# Altium schematic sheet transmitter.SchDoc(transmitter)
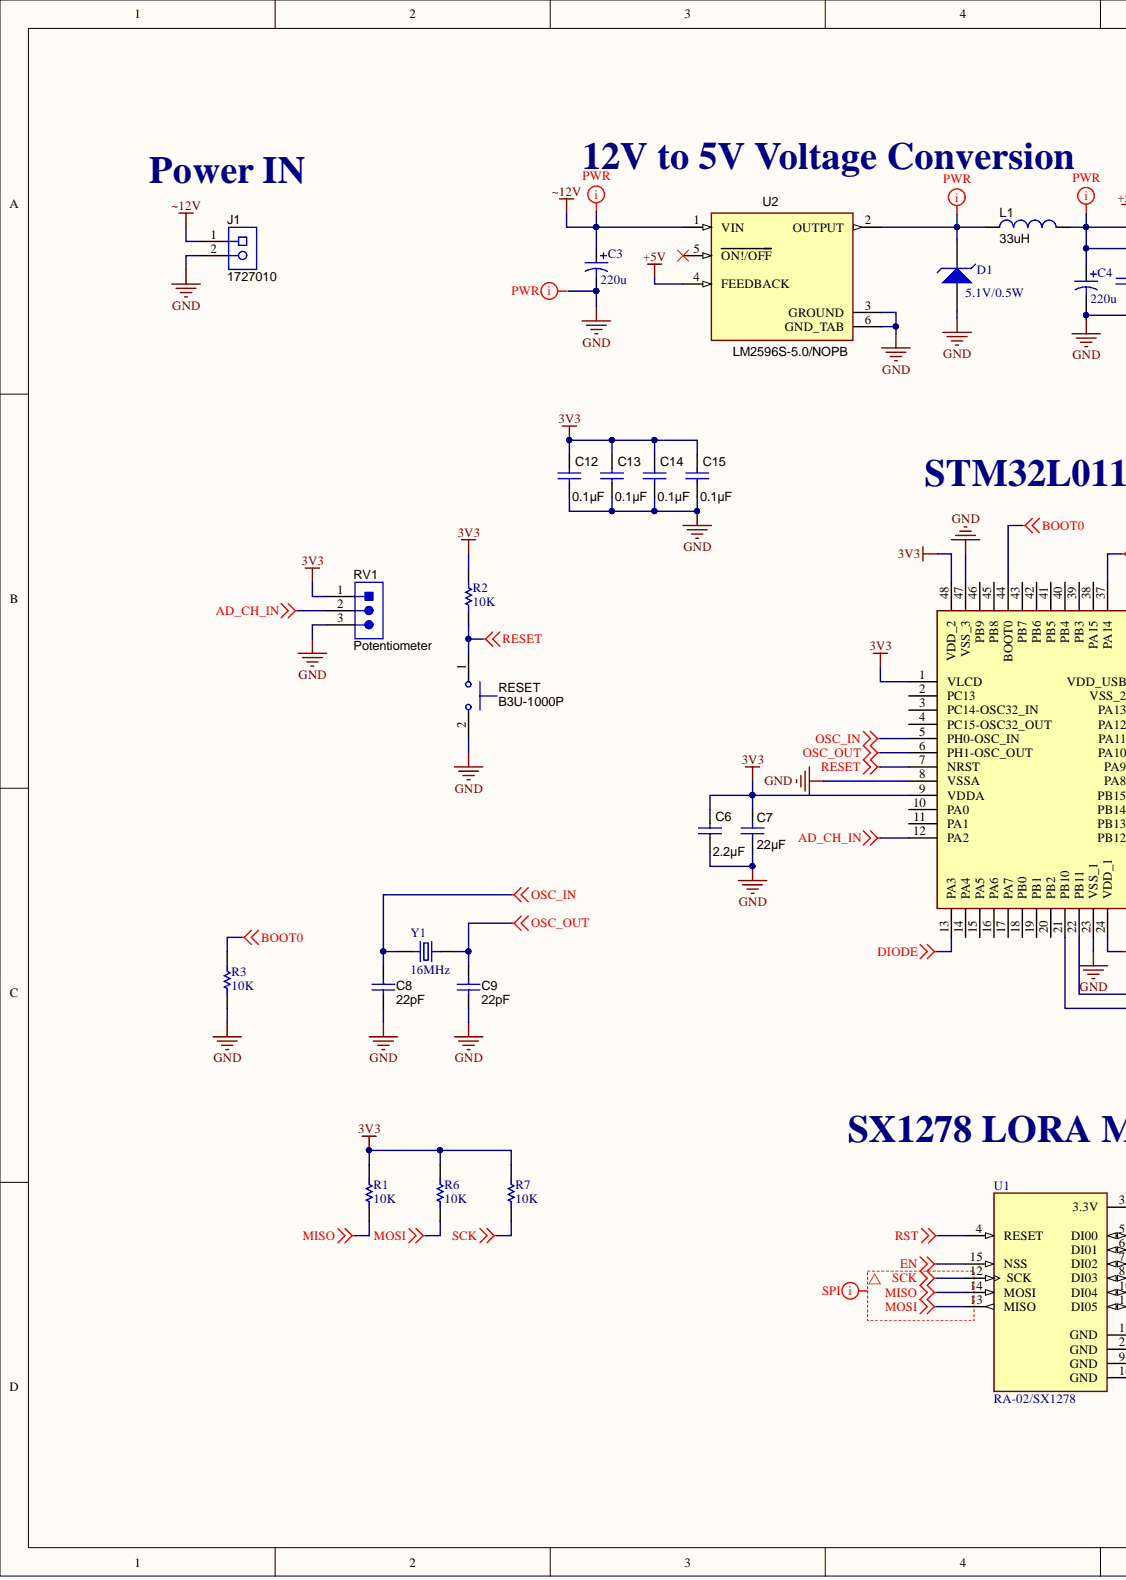
[diagram p0: transmitter.SchDoc, left]
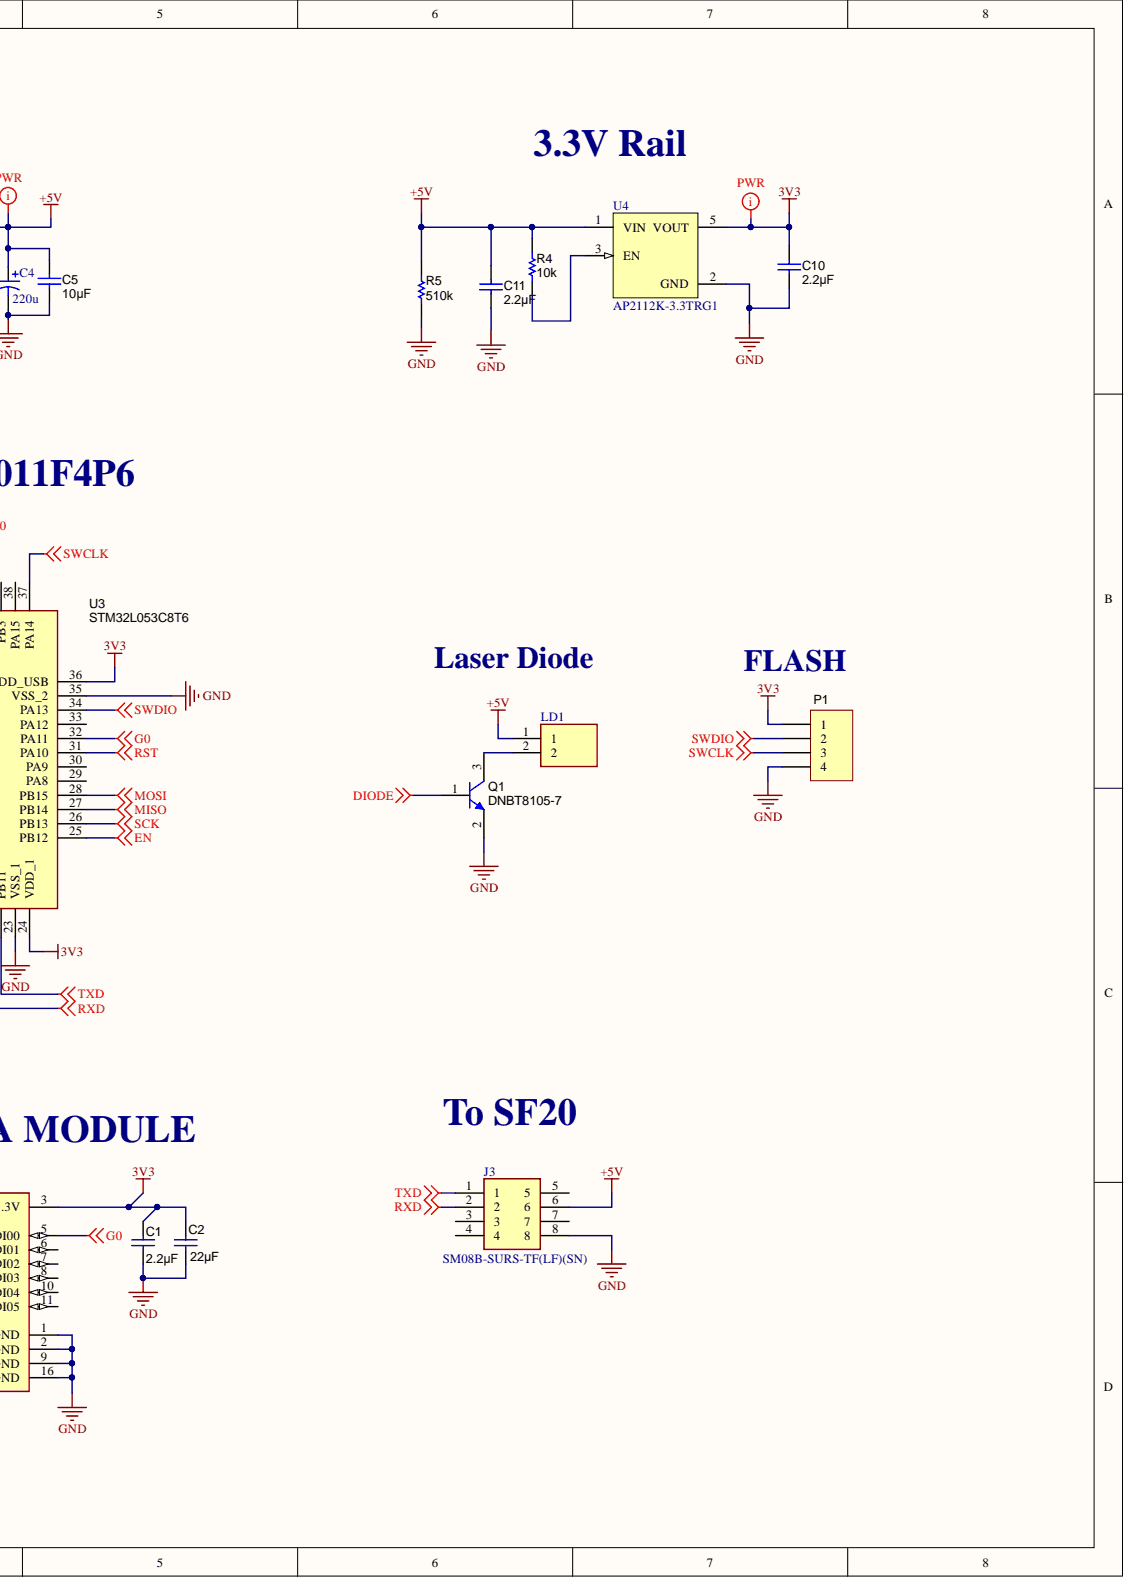
[diagram p1: transmitter.SchDoc, right]

## Parts
C1  ValueDisplayed: 2.2µF  [0603]  (hidden comment: C1608X6S1C225K080AC)
C2  ValueDisplayed: 22µF  [1206_180]  (hidden comment: C3216X5R1V226M160AC)
C3  220u  [CAPPRD250W50D630H1120B]
C4  220u  [CAPPRD250W50D630H1120B]
C5  ValueDisplayed: 10µF  [0805_HV]  (hidden comment: EMK212BB7106MG-T)
C6  ValueDisplayed: 2.2µF  [0603]  (hidden comment: C1608X6S1C225K080AC)
C7  ValueDisplayed: 22µF  [1206_180]  (hidden comment: C3216X5R1V226M160AC)
C8  value 22pF  ValueDisplayed: 22pF  [0805_HV]  (hidden comment: 0805YD225KAT2A)
C9  value 22pF  ValueDisplayed: 22pF  [0805_HV]  (hidden comment: 0805YD225KAT2A)
C10  ValueDisplayed: 2.2µF  [0805_HV]  (hidden comment: 0805YD225KAT2A)
C11  ValueDisplayed: 2.2µF  [0805_HV]  (hidden comment: 0805YD225KAT2A)
C12  ValueDisplayed: 0.1µF  [0603]  (hidden comment: C1608X6S1C225K080AC)
C13  ValueDisplayed: 0.1µF  [0603]  (hidden comment: C1608X6S1C225K080AC)
C14  ValueDisplayed: 0.1µF  [0603]  (hidden comment: C1608X6S1C225K080AC)
C15  ValueDisplayed: 0.1µF  [0603]  (hidden comment: C1608X6S1C225K080AC)
D1  5.1V/0.5W  [SOD-123]
J1  1727010  [PhoenixConact_1727010]
J3  SM08B-SURS-TF(LF)(SN)  [SM08B-SURS-TF]
L1  33uH  [IND_MSS1210-153MEB]
LD1  Header-2pin(2.5mm)  [B2B-XH-A(LF)(SN)]  (value not drawn)
P1  0901471104  [HDR1X4]  (value not drawn)
Q1  DNBT8105-7  [SOT-23]
R1  10K  [R0805]
R2  10K  [R0805]
R3  10K  [R0805]
R4  value 10k  ValueDisplayed: 10k  [0603]  (hidden comment: CRCW060310K0JNEA)
R5  value 510k  ValueDisplayed: 510k  [0805_HV]  (hidden comment: CRCW0805510KJNEA)
R6  10K  [R0805]
R7  10K  [R0805]
RESET  B3U-1000P  [SW_B3U-1000P]
RV1  Potentiometer  [WURTH_61300311121]
U1  RA-02/SX1278  [MODULE_RA-02_LORA]
U2  LM2596S-5.0/NOPB  [TO170P1524X483-6N]
U3  STM32L053C8T6  [QFP50P900X900X160-48N]
U4  AP2112K-3.3TRG1  [SOT95P285X140-5N]
Y1  16MHz  [XTAL_ECS-160-20-4XDN]

## Nets
3V3: C1.1 C2.1 C6.1 C7.1 C10.1 C12.1 C13.1 C14.1 C15.1 P1.1 R1.2 R2.2 R6.2 R7.2 RV1.1 U1.3(3.3V) U3.1(VLCD) U3.9(VDDA) U3.24(VDD_1) U3.36(VDD_USB) U3.48(VDD_2) U4.5(VOUT)
+5V: C4.1 C5.1 C11.1 J3.6 L1.2 LD1.1 R4.2 R5.2 U2.4(FEEDBACK) U4.1(VIN)
AD_CH_IN: RV1.2 U3.12(PA2)
BOOT0: R3.2 U3.44(BOOT0)
DIODE: Q1.1 U3.13(PA3)
EN: U1.15(NSS) U3.25(PB12)
G0: U1.5(DI00) U3.32(PA11)
GND: C1.2 C2.2 C3.2 C4.2 C5.2 C6.2 C7.2 C8.2 C9.2 C10.2 C11.2 C12.2 C13.2 C14.2 C15.2 D1.1 J1.2 J3.8 P1.4 Q1.2 R3.1 R5.1 RESET.2 RV1.3 U1.1(GND) U1.2(GND) U1.9(GND) U1.16(GND) U2.3(GROUND) U2.6(GND_TAB) U3.8(VSSA) U3.23(VSS_1) U3.35(VSS_2) U3.47(VSS_3) U4.2(GND)
MISO: R1.1 U1.14(MOSI) U3.27(PB14)
MOSI: R6.1 U1.13(MISO) U3.28(PB15)
OSC_IN: C8.1 U3.5(PH0-OSC_IN) Y1.1
OSC_OUT: C9.1 U3.6(PH1-OSC_OUT) Y1.2
RESET: R2.1 RESET.1 U3.7(NRST)
RST: U1.4(RESET) U3.31(PA10)
RXD: J3.2 U3.21(PB10)
SCK: R7.1 U1.12(SCK) U3.26(PB13)
SWCLK: P1.3 U3.37(PA14)
SWDIO: P1.2 U3.34(PA13)
TXD: J3.1 U3.22(PB11)
~12V: C3.1 J1.1 U2.1(VIN)
NetD1_2: D1.2 L1.1 U2.2(OUTPUT)
NetLD1_2: LD1.2 Q1.3
NetR4_1: R4.1 U4.3(EN)
N00048:
N00049:

Not connected: J3 3 4 5 7; U1 6(DI01) 7(DI02) 8(DI03) 10(DI04) 11(DI05); U2 5(ON!/OFF); U3 2(PC13) 3(PC14-OSC32_IN) 4(PC15-OSC32_OUT) 10(PA0) 11(PA1) 14(PA4) 15(PA5) 16(PA6) 17(PA7) 18(PB0) 19(PB1) 20(PB2) 29(PA8) 30(PA9) 33(PA12) 38(PA15) 39(PB3) 40(PB4) 41(PB5) 42(PB6) 43(PB7) 45(PB8) 46(PB9)

## Library data of the parts
C1 C6 C12-C15 = C1608X6S1C225K080AC: CAP, CERM, 2.2 µF, 16 V, +/- 10%, X6S, 0603; Manufacturer: TDK; VDC_V: 16; Value2: 16V; Value1: 0.007674 ohm; Value: 2.2uF; U/M: EA; Tolerance_%: 10; TaMin_C: -55; TaMax_C: 105; SizeZ_mm: 1; SizeY_mm: 0.8; SizeX_mm: 1.6; SizeAreaWB_mm2: 4.68; SizeArea_mm2: 1.28; Polarized: N; IRMS_A: 1.87823; ESR_ohm: 0.007674; CompType: Capacitor; Cap_F: 0.0000022; AEC Category: Q200; TempCo: X6S; Technology: Ceramic; SymbolVersion: 2008-07-09; Supplier Part Number 2: 810-C1608X6S1C225K080AC; Supplier Part Number 1: 445-7438-1-ND; Supplier 2: Mouser; Supplier 1: Digi-Key; Simulation: Cap; Signal Integrity: Cap; RoHS: Y; Published: 2008-06-19; PartNumber: C1608X6S1C225K080AC; PackageReference: 0603; MountType: SMT; LatestRevisionDate: 2017-04-28; ComponentLink1URL: https://product.tdk.com/en/search/capacitor/ceramic/mlcc/info?part_no=C1608X6S1C225K080AC; ComponentLink1Description: Datasheet link; Component Kind: Standard; Cap_Case: 0603; AEC Qualified: N; AEC Grade: N/A; Publisher: Texas Instruments; Library Name: FAMIS.SchLib
C2 C7 = C3216X5R1V226M160AC: CAP, CERM, 22 µF, 35 V,+/- 20%, X5R, 1206; Manufacturer: TDK; VDC_V: 35; Value2: 35V; Value1: 0.002398 ohm; Value: 22uF; U/M: EA; Tolerance_%: 20; TaMin_C: -55; TaMax_C: 85; SizeZ_mm: 1.8; SizeY_mm: 1.6; SizeX_mm: 3.2; SizeAreaWB_mm2: 10.92; SizeArea_mm2: 5.12; Polarized: N; IRMS_A: 4.68507; Green_REACh: Passed; Green_Lifecycle: Active; ESR_ohm: 0.002398; CompType: Capacitor; Cap_F: 0.000022; Cap_Case: 1206; AEC Category: Q200; TempCo: X5R; Technology: Ceramic; SymbolVersion: 2008-07-09; Supplier Part Number 3: 810-C3216X5R1V226M; Supplier Part Number 2: 04X3299; Supplier Part Number 1: 445-8045-1-ND; Supplier 3: Mouser; Supplier 2: Newark; Supplier 1: Digi-Key; Simulation: Cap; Signal Integrity: Cap; RoHS: Y; Published: 2008-06-19; PartNumber: C3216X5R1V226M160AC; PackageReference: 1206; MountType: SMT; LatestRevisionDate: 2017-06-22; Green_EU RoHS-2: Passed; Green_EU RoHS: Passed; ComponentLink1URL: https://product.tdk.com/en/search/capacitor/ceramic/mlcc/info?part_no=C3216X5R1V226M160AC; ComponentLink1Description: Datasheet link; Component Kind: Standard; AEC Qualified: N; AEC Grade: N/A; Publisher: Texas Instruments; Library Name: FAMIS.SchLib
C3 C4 = UCS2C221MHD1TN: CAP ALUM 220UF 20% 160V RADIAL; Manufacturer: Nichicon; Ripple Current: 2.37A; Life (Hours): 10000Hour; Lead Diameter: 0.8mm; Voltage Rating: 160V; Tolerance: 20%; Termination: Radial; Supplier Part Number 1: 493-13277-3-ND; Supplier 1: Digi-Key; Stock 1: 84250; Radiation Hardening: No; Pricing 1: 250=0.7974, 500=0.6778, 750=0.6379, 1250=0.5981, 2500=0.5582, 6250=0.5383, 12500=0.5084, 25000=0.4984 (USD); Packaging: Tape and Reel; Package Quantity: 250; Mount: Through Hole; Min Operating Temperature: -40°C; Max Operating Temperature: 105°C; Manufacturer Part Number: UCS2C221MHD1TN; Lead Pitch: 7.5mm; Height - Seated (Max): 1.063inch; Height: 25mm; Diameter: 16mm; ComponentLink4URL: http://www.nichicon.co.jp/english/products/pdfs/e-cs.pdf?src-supplier=Avnet+Europe; ComponentLink4Description: http://www.nichicon.co.jp/english/products/pdfs/e-cs.pdf?src-supplier=Avnet+Europe; ComponentLink3URL: http://nichicon-us.com/english/products/pdfs/e-ch_ta.pdf?src-supplier=Digi-Key; ComponentLink3Description: http://nichicon-us.com/english/products/pdfs/e-ch_ta.pdf?src-supplier=Digi-Key; ComponentLink2URL: http://nichicon-us.com/english/products/pdfs/e-ucs.pdf?src-supplier=Digi-Key; ComponentLink2Description: http://nichicon-us.com/english/products/pdfs/e-ucs.pdf?src-supplier=Digi-Key; ComponentLink1URL: http://www.nichicon.co.jp/english/products/pdfs/e-cs.pdf?src-supplier=Avnet; ComponentLink1Description: http://www.nichicon.co.jp/english/products/pdfs/e-cs.pdf?src-supplier=Avnet; Component Kind: Standard; Case/Package: Radial; Capacitance: 220uF; Library Name: FAMIS.SchLib
C5 = EMK212BB7106MG-T: CAP, CERM, 10 µF, 16 V, +/- 20%, X7R, 0805; Manufacturer: Taiyo Yuden; VDC_V: 16; Value2: 16V; Value1: Unknown; Value: 10uF; U/M: EA; Tolerance_%: 20; TaMin_C: -55; TaMax_C: 125; SizeZ_mm: 1.45; SizeY_mm: 1.25; SizeX_mm: 2; SizeAreaWB_mm2: 6.75; SizeArea_mm2: 2.5; Polarized: N; CompType: Capacitor; Cap_F: 0.00001; Cap_Case: 0805; AEC Category: Q200; TempCo: X7R; Technology: Ceramic; SymbolVersion: 2008-07-09; Supplier Part Number 2: 963-EMK212BB7106MG-T; Supplier Part Number 1: 587-3319-1-ND; Supplier 2: Mouser; Supplier 1: Digi-Key; Simulation: Cap; Signal Integrity: Cap; RoHS: Y; Published: 2008-06-19; PartNumber: EMK212BB7106MG-T; PackageReference: 0805; MountType: SMT; LatestRevisionDate: 2017-01-20; ComponentLink1URL: http://www.yuden.co.jp/productdata/catalog/en/mlcc_all_e.pdf; ComponentLink1Description: Datasheet link; Component Kind: Standard; AEC Qualified: ?; Publisher: Texas Instruments; Library Name: FAMIS.SchLib
C8 C9 = 0805YD225KAT2A: CAP, CERM, 2.2 µF, 16 V, +/- 10%, X5R, 0805; Manufacturer: AVX; VDC_V: 16; Value2: 16V; Value1: 0.009 ohm; U/M: EA; Tolerance_%: 10; TaMin_C: -55; TaMax_C: 85; Polarized: N; ESR_ohm: 0.009; CompType: Capacitor; Cap_F: 0.0000022; Cap_Case: 0805; TempCo: X5R; Technology: Ceramic; SymbolVersion: 2008-07-09; Supplier Part Number 3: 1650715; Supplier Part Number 2: 581-0805YD225K; Supplier Part Number 1: 478-2580-1-ND; Supplier 3: Farnell; Supplier 2: Mouser; Supplier 1: Digi-Key; Simulation: Cap; Signal Integrity: Cap; RoHS: Y; Published: 2008-06-19; PartNumber: 0805YD225KAT2A; PackageReference: 0805; MountType: SMT; LatestRevisionDate: 2014-12-30; ComponentLink1URL: http://www.avx.com/docs/masterpubs/smccp.pdf; ComponentLink1Description: Datasheet link; Component Kind: Standard; Publisher: Texas Instruments; Library Name: FAMIS.SchLib
C10 C11 = 0805YD225KAT2A: CAP, CERM, 2.2 µF, 16 V, +/- 10%, X5R, 0805; Manufacturer: AVX; VDC_V: 16; Value2: 16V; Value1: 0.009 ohm; Value: 2.2uF; U/M: EA; Tolerance_%: 10; TaMin_C: -55; TaMax_C: 85; Polarized: N; ESR_ohm: 0.009; CompType: Capacitor; Cap_F: 0.0000022; Cap_Case: 0805; TempCo: X5R; Technology: Ceramic; SymbolVersion: 2008-07-09; Supplier Part Number 3: 1650715; Supplier Part Number 2: 581-0805YD225K; Supplier Part Number 1: 478-2580-1-ND; Supplier 3: Farnell; Supplier 2: Mouser; Supplier 1: Digi-Key; Simulation: Cap; Signal Integrity: Cap; RoHS: Y; Published: 2008-06-19; PartNumber: 0805YD225KAT2A; PackageReference: 0805; MountType: SMT; LatestRevisionDate: 2014-12-30; ComponentLink1URL: http://www.avx.com/docs/masterpubs/smccp.pdf; ComponentLink1Description: Datasheet link; Component Kind: Standard; Publisher: Texas Instruments; Library Name: FAMIS.SchLib
D1 = 5.1V/0.5W zener: Diode Zener Single 5.1V 5% 500mW 2-Pin SOD-123 T/R; Manufacturer Part Number: MMSZ5231B-7-F; Manufacturer: Diodes; Zener Current: 5uA; Test Current: 20mA; Impedance: 17R; Forward Voltage: 900mV; ESD Protection: No; Zener Voltage: 5.1V; Working Voltage: 5.1V; Width: 1.7mm; Weight: 0.000353oz; Voltage Tolerance: 5%; Voltage Rating (DC): 5.1V; Tolerance: 5%; Termination: SMD/SMT; Supplier Part Number 1: MMSZ5231B-FDIDKR-ND; Supplier 1: Digi-Key; Stock 1: 856806; REACH SVHC: No SVHC; Radiation Hardening: No; Pricing 1: 1=0.14, 10=0.126, 100=0.0596, 500=0.0354, 1000=0.0232 (USD); Power Rating: 500mW; Power Dissipation: 500mW; Packaging: Tape and Reel; Package Quantity: 3000; Number of Pins: 2; Mount: Surface Mount; Min Operating Temperature: -65°C; Max Reverse Leakage Current: 5uA; Max Reverse Current: 5uA; Max Power Dissipation: 500mW; Max Operating Temperature: 150°C; Length: 3.85mm; Lead Free: Lead Free; Height: 1.35mm; Element Configuration: Single; Contact Plating: Tin,Matte; ComponentLink3URL: https://www.verical.com/server-webapp/html/getDatasheet?partID=141394&src-supplier=Verical; ComponentLink3Description: https://www.verical.com/server-webapp/html/getDatasheet?partID=141394&src-supplier=Verical; ComponentLink2URL: http://www.mouser.com/ds/2/115/ds18010-38133.pdf?src-supplier=Mouser; ComponentLink2Description: http://www.mouser.com/ds/2/115/ds18010-38133.pdf?src-supplier=Mouser; ComponentLink1URL: http://www.jameco.com/Jameco/Products/ProdDS/1541116.pdf?src-supplier=Jameco; ComponentLink1Description: http://www.jameco.com/Jameco/Products/ProdDS/1541116.pdf?src-supplier=Jameco; Component Kind: Standard; Case/Package: SOD-123-2; Library Name: FAMIS.SchLib
J1 = 1727010: Conn Term Block, 2POS, 3.81mm, TH; Manufacturer: Phoenix Contact; SymbolCreator: a0412235; CompType: Terminal Block; SymbolVersion: 2013-09-04; Supplier Part Number 1: 277-1947-ND; Supplier 1: Digi-Key; RoHS: Y; PartNumber: 1727010; PackageReference: 2POS Terminal Block; MountType: TH; LatestRevisionDate: 2016-07-13; ComponentLink1URL: http://eshop.phoenixcontact.com/phoenix/pdf.do?UID=1727010&general=usen; ComponentLink1Description: Datasheet URL; Component Kind: Standard; Publisher: Texas Instruments; Library Name: FAMIS.SchLib
J3 = SM08B-SURS-TF(LF)(SN): CONN HEADER SMD R/A 8POS 0.8MM; Manufacturer: JST; Working Voltage: 30V; Wire/Cable Gauge: 32AWG; Voltage Rating: 50V; Pitch: 0.031inch; Number of Positions: 8; Insulation Resistance: 100MR; Gender: Male; Current Rating: 500mA; Connector Type: Outer Shroud Contact; Body Plating: Tin; Termination: IDC; Supplier Part Number 1: 455-2040-6-ND; Supplier 1: Digi-Key; Stock 1: 2871; Series: SUR; REACH SVHC: No SVHC; Pricing 1: 1=1.09, 10=0.958, 100=0.8263, 500=0.6761, 1000=0.6009 (USD); Packaging: Tape and Reel; Number of Rows: 1; Number of Poles: 8; Number of Contacts: 8; Mount: Surface Mount; Min Operating Temperature: -25°C; Max Operating Temperature: 85°C; Material: Polyamide; Manufacturer Part Number: SM08B-SURS-TF(LF)(SN); Lead Pitch: 0.8mm; Lead Free: Lead Free; Insulation Material: Nylon; Flammability Rating: UL94 V-0; Contact Type: Outer Shroud Contact; Contact Plating: Tin; Contact Material: Copper Alloy,Bronze,Brass; ComponentLink2URL: http://www.jst-mfg.com/product/pdf/eng/eSUR.pdf?src-supplier=Future+Electronics; ComponentLink2Description: http://www.jst-mfg.com/product/pdf/eng/eSUR.pdf?src-supplier=Future+Electronics; ComponentLink1URL: http://www.jst-mfg.com/product/pdf/eng/eSUR.pdf?src-supplier=Digi-Key; ComponentLink1Description: http://www.jst-mfg.com/product/pdf/eng/eSUR.pdf?src-supplier=Digi-Key; Component Kind: Standard; Color: Natural; Library Name: FAMIS.SchLib
L1 = MSS1210-333MEB: Inductor COILCRAFT         MSS1210-333MEB             Surface Mount Power Inductor, MSS1210 Series, 1 mH, 1 A, 980 mA, Shielded, 1.03 ohm; Manufacturer Part Number: MSS1210-105KED; Tolerance: 10%; Test Frequency: 100kHz; Self Resonant Frequency: 1.1MHz; Saturation Current: 980mA; RMS Current (Irms): 1A; Inductance: 1mH; DC Resistance (DCR): 1.03R; Core Material: Ferrite; Width: 12.3mm; Termination: SMD/SMT; Supplier Part Number 1: 994-MSS1210-105KED; Supplier 1: Mouser; Stock 1: 518; Shielding: Shielded; Series: MSS1210; REACH SVHC: No SVHC; Pricing 1: 1=2.26, 10=2.02, 50=1.82, 100=1.62, 1000=1.17, 10000=1.09 (USD); Packaging: Tape and Reel; Package Quantity: 300; Mount: Surface Mount; Min Operating Temperature: -40°C; Max Operating Temperature: 85°C; Max DC Current: 1A; Manufacturer: Coilcraft; Length: 12.3mm; Lead Free: Contains Lead; Inductance Tolerance: 10%; Height: 10.2mm; ComponentLink2URL: http://www.mouser.com/ds/2/597/mss1210-270677.pdf?src-supplier=Mouser; ComponentLink2Description: http://www.mouser.com/ds/2/597/mss1210-270677.pdf?src-supplier=Mouser; ComponentLink1URL: http://www.farnell.com/datasheets/1681953.pdf?src-supplier=Element14; ComponentLink1Description: http://www.farnell.com/datasheets/1681953.pdf?src-supplier=Element14; Component Kind: Standard; Case/Package: SMD/SMT; Library Name: FAMIS.SchLib
LD1 = B2B-XH-A(LF)(SN): Conn Shrouded Header (4 Sides) HDR 2 POS 2.5mm Solder ST Thru-Hole Box; Manufacturer: JST; Wire/Cable Gauge: 22AWG; Voltage Rating (AC): 250V; Number of Positions: 2; Max Current Rating: 3A; Insulation Resistance: 1GR; Contact Resistance: 20mR; Connector Type: Male Pin; Color: Natural; Voltage Rating: 250V; Termination: Solder; Supplier Part Number 1: 455-2247-ND; Supplier 1: Digi-Key; Stock 1: 124367; Series: XH; REACH SVHC: No SVHC; Pricing 1: 1=0.15, 10=0.141, 25=0.1048, 50=0.0898, 250=0.0748, 750=0.0673, 1000=0.0628, 12000=0.0479, 24000=0.0434 (USD); Pitch: 2.5mm; Packaging: Bulk; Orientation: Straight; Number of Rows: 1; Number of Contacts: 2; Mount: Through Hole; Min Operating Temperature: -25°C; Max Voltage Rating (DC): 250V; Max Voltage Rating (AC): 250V; Max Operating Temperature: 85°C; Manufacturer Part Number: B2B-XH-A(LF)(SN); Length: 7.4mm; Lead Pitch: 2.5mm; Lead Free: Lead Free; Housing Material: Polyamide; Housing Color: White; Fastening Type: Detent; Depth: 5.75mm; Current Rating: 3A; Contact Type: Male Pin; Contact Plating: Tin,Copper,Lead; Contact Material: Brass; ComponentLink4URL: https://www.verical.com/server-webapp/html/getDatasheet?partID=385291&src-supplier=Verical; ComponentLink4Description: https://www.verical.com/server-webapp/html/getDatasheet?partID=385291&src-supplier=Verical; ComponentLink3URL: https://www.verical.com/server-webapp/html/getDatasheet?partID=640357&src-supplier=Verical; ComponentLink3Description: https://www.verical.com/server-webapp/html/getDatasheet?partID=640357&src-supplier=Verical; ComponentLink2URL: http://www.rapidonline.com/pdf/523653.pdf?src-supplier=Rapid+Electronics; ComponentLink2Description: http://www.rapidonline.com/pdf/523653.pdf?src-supplier=Rapid+Electronics; ComponentLink1URL: http://www.farnell.com/datasheets/2057209.pdf?src-supplier=Element14; ComponentLink1Description: http://www.farnell.com/datasheets/2057209.pdf?src-supplier=Element14; Component Kind: Standard; Library Name: FAMIS.SchLib
P1 = 0901471104: Conn Socket Strip F 4 POS 2.54mm Solder ST Thru-Hole C-Grid® Tube; Manufacturer: Molex; Pitch: 0.1inch; Number of Positions: 4; Connector Type: Receptacle; Termination: Solder; Supplier Part Number 1: WM14831-ND; Supplier 1: Digi-Key; Stock 1: 23124; Series: C-Grid® 90147; Pricing 1: 1=1.51, 10=1.288, 100=1.064, 1000=0.84, 5000=0.7448, 10000=0.728 (USD); Packaging: Rail/Tube; Package Quantity: 4160; Orientation: Straight; Number of Rows: 1; Number of Contacts: 4; Mount: Through Hole; Min Operating Temperature: -55°C; Max Operating Temperature: 105°C; Manufacturer Part Number: 0901471104; Length: 10.16mm; Housing Material: Polyester; Housing Color: Black; Depth: 2.54mm; Contact Type: Female Socket; Contact Plating: Tin; Contact Material: Bronze; ComponentLink1URL: http://www.molex.com/pdm_docs/sd/901471102_sd.pdf?src-supplier=Digi-Key; ComponentLink1Description: http://www.molex.com/pdm_docs/sd/901471102_sd.pdf?src-supplier=Digi-Key; Component Kind: Standard; Color: Black; Library Name: FAMIS.SchLib
Q1 = DNBT8105-7, Diodes Inc.: Transistor, NPN, 60 V, 1 A, AEC-Q101, SOT-23; Vcesat1_V: 0.25; Vceo_V: 60; Value2: 300; Value1: 1 A; Value: 60 V; U/M: EA; TaMin_C: -55; TaMax_C: 150; SizeZ_mm: 1.1; SizeY_mm: 3; SizeX_mm: 2.5; SizeAreaWB_mm2: 14; SizeArea_mm2: 7.5; PNP: 0; NPN: 1; CompType: BJT; AEC Qualified: Y; AEC Category: Q101; SymbolVersion: 2008-07-31; Supplier Part Number 3: 621-DNBT8105-7; Supplier Part Number 2: 25R4543; Supplier Part Number 1: DNBT8105DICT-ND; Supplier 3: Mouser; Supplier 2: Newark; Supplier 1: Digi-Key; RoHS: Y; Published: 2008-07-31; PartNumber: DNBT8105-7; PackageReference: SOT-23; MountType: SMT; Manufacturer: Diodes Inc.; LatestRevisionDate: 2017-04-05; IcRated_A: 1; HfeMax: 300; ComponentLink1URL: https://www.diodes.com/assets/Datasheets/ds30513.pdf; ComponentLink1Description: Datasheet link; Component Kind: Standard; Publisher: Texas Instruments; Library Name: FAMIS.SchLib
R1-R3 R6 R7 = RC0805FR-0722RL: RES SMD 22 OHM 1% 1/8W 0805; Manufacturer: Yageo; Temperature Coefficient: ±100ppm/°C; Resistance (Ohms): 22; Power (Watts): 0.125W, 1/8W; .Creator: MM; .Checked SCH: RF; Tolerance: ±1%; Supplier Part Number 1: 311-22.0CRCT-ND; Supplier Device Package: 0805; Supplier 1: Digi-Key; Stock 1: 63849; Size / Dimension: 0.079" L x 0.049" W (2.00mm x 1.25mm); RoHS: RoHS Compliant; Pricing 1: 1=0.1, 10=0.021, 25=0.0148, 100=0.0084, 250=0.0064, 500=0.0051, 1000=0.00376, 2500=0.00326 (USD); Packaging: Cut Tape (CT); Package / Case: 0805 (2012 Metric); Operating Temperature: -55°C ~ 155°C; Number of Terminations: 2; Manufacturer Part Number: RC0805FR-0722RL; Height: 0.024" (0.60mm); Features: Moisture Resistant; Composition: Thick Film; ComponentLink1URL: http://www.yageo.com/documents/recent/PYu-RC_Group_51_RoHS_L_1.pdf; ComponentLink1Description: http://www.yageo.com/documents/recent/PYu-RC_Group_51_RoHS_L_1.pdf; Component Kind: Standard; Category: Resistors; Library Name: FAMIS.SchLib; .Checked PCB: RF
R4 = CRCW060310K0JNEA: RES, 10 k, 5%, 0.1 W, 0603; Manufacturer: Vishay-Dale; VDC_V: 75; Value_Code: 10k0; Value2: 0.1W; U/M: EA; Tolerance_%: 5; TempCo: 200 ppm/C; SizeZ_mm: 1; SizeY_mm: 0.8; SizeX_mm: 1.6; SizeArea_mm2: 1.28; Res_ohm: 10000; Power_W: 0.1; EIA_Code: E24; CompType: Resistor; AEC Category: Q200; Value1: 5%; Technology: Thick Film; SymbolVersion: 2009-05-29; Supplier Part Number 3: 1469749; Supplier Part Number 2: 71-CRCW0603J-10K-E3; Supplier Part Number 1: 541-10KGCT-ND; Supplier 3: Farnell; Supplier 2: Mouser; Supplier 1: Digi-Key; Simulation: Resistor; Signal Integrity: Resistor; RoHS: Y; Published: 2008-06-26; PartNumber: CRCW060310K0JNEA; PackageReference: 0603; MountType: SMT; LatestRevisionDate: 2016-07-29; ComponentLink1URL: http://www.vishay.com/docs/20035/dcrcwe3.pdf; ComponentLink1Description: Datasheet link; Component Kind: Standard; AEC Qualified: ?; Publisher: Texas Instruments; Library Name: FAMIS.SchLib
R5 = CRCW0805510KJNEA: RES, 510 k, 5%, 0.125 W, 0805; Manufacturer: Vishay-Dale; VDC_V: 150; Value2: 0.125W; U/M: EA; Tolerance_%: 5; TempCo: 200 ppm/C; Res_ohm: 510000; Power_W: 0.125; EIA_Code: E24; CompType: Resistor; AEC Category: Q200; Value_Code: 510k; Value1: 5%; Technology: Thick Film; SymbolVersion: 2009-05-29; Supplier Part Number 3: 1537987; Supplier Part Number 2: 71-CRCW0805J-510K-E3; Supplier Part Number 1: 541-510KACT-ND; Supplier 3: Farnell; Supplier 2: Mouser; Supplier 1: Digi-Key; Simulation: Resistor; Signal Integrity: Resistor; RoHS: Y; Published: 2008-06-26; PartNumber: CRCW0805510KJNEA; PackageReference: 0805; MountType: SMT; LatestRevisionDate: 2016-05-05; ComponentLink1URL: http://www.vishay.com/docs/20035/dcrcwe3.pdf; ComponentLink1Description: Datasheet link; Component Kind: Standard; AEC Qualified: ?; Publisher: Texas Instruments; Library Name: FAMIS.SchLib
RESET = B3U-1000P: SWITCH TACTILE SPST-NO 0.05A 12V; Manufacturer: Omron Electronic Components; VDC_V: 12; SymbolCreator: a0412235; SwitchFunction: Off-Mom; PositionCount: 1; CurrentRating_mA: 50; SymbolVersion: 2012-10-29; Supplier Part Number 3: 1333652; Supplier Part Number 2: 653-B3U-1000P; Supplier Part Number 1: SW1020CT-ND; Supplier 3: Farnell; Supplier 2: Mouser; Supplier 1: Digi-Key; RoHS: Y; PartNumber: B3U-1000P; PackageReference: 3x1.6x2.5mm; Orientation: Top Actuated; MountType: SMT; LatestRevisionDate: 2015-08-27; CompType: Switch; ComponentLink1URL: http://www.components.omron.com/components/web/PDFLIB.nsf/0/2DD3893C7DD33E9E862572900079E9D6/$file/B3U_1110.pdf; ComponentLink1Description: Datasheet URL; Component Kind: Standard; Circuit: SPST-NO; Publisher: Texas Instruments; Library Name: FAMIS.SchLib
RV1 = 61300311121: Header, 2.54 mm, 3x1, Gold, TH; Manufacturer: Wurth Elektronik; U/M: EA; SymbolCreator: a0799628; SymbolVersion: 2013-03-28; Supplier Part Number 1: 732-5316-ND; Supplier 1: Digi-Key; RoHS: Y; PartNumber: 61300311121; PackageReference: Header, 2.54mm, 3x1, TH; MountType: TH; LatestRevisionDate: 2015-05-12; CompType: Header; ComponentLink1URL: http://katalog.we-online.de/em/datasheet/6130xx11121.pdf; ComponentLink1Description: Datasheet Link; Component Kind: Standard; ClassName: Header; Publisher: Texas Instruments; Library Name: FAMIS.SchLib
U1 = RA-02: LoRA module; Component Kind: Standard; Library Name: FAMIS.SchLib
U2 = LM2596S-5.0/NOPB: Conv DC-DC 4.5V to 40V Inv/Step Down Single-Out 5V 3A 6-Pin(5+Tab) TO-263 Tube; Manufacturer: TI National Semiconductor; Switching Frequency: 173kHz; Quiescent Current: 5mA; Output Type: Fixed; Number of Outputs: 1; Efficiency: 80%; Width: 8.64mm; Weight: 0.25lb; Supplier Part Number 1: 926-LM2596S-5.0/NOPB; Supplier 1: Mouser; Stock 1: 1433; Series: LM2596; REACH SVHC: No SVHC; Radiation Hardening: No; Pricing 1: 1=5.73, 10=5.15, 50=4.86, 100=4, 1000=2.88, 10000=2.77 (USD); Packaging: Rail/Tube; Package Quantity: 45; Output Voltage: 5V; Output Current: 3A; Operating Supply Voltage: 40V; Number of Pins: 5; Nominal Output Voltage: 5V; Mount: Surface Mount; Min Operating Temperature: -40°C; Min Input Voltage: 4.5V; Max Output Current: 3A; Max Operating Temperature: 125°C; Max Input Voltage: 40V; Manufacturer Part Number: LM2596S-5.0/NOPB; Length: 10.16mm; Lead Free: Contains Lead; Height: 4.57mm; Contact Plating: Tin,Matte; ComponentLink7URL: http://www.produktinfo.conrad.com/datenblaetter/1000000-1099999/001013813-da-01-en-IC_REG_BUC_LM2596S_5_0_NOPB_TO_263_5_TID.pdf?src-supplier=Conrad; ComponentLink7Description: http://www.produktinfo.conrad.com/datenblaetter/1000000-1099999/001013813-da-01-en-IC_REG_BUC_LM2596S_5_0_NOPB_TO_263_5_TID.pdf?src-supplier=Conrad; ComponentLink6URL: http://www.jameco.com/Jameco/Products/ProdDS/836385.pdf?src-supplier=Jameco; ComponentLink6Description: http://www.jameco.com/Jameco/Products/ProdDS/836385.pdf?src-supplier=Jameco; ComponentLink5URL: http://www.ti.com/lit/ds/symlink/lm2596.pdf?src-supplier=Avnet+Asia; ComponentLink5Description: http://www.ti.com/lit/ds/symlink/lm2596.pdf?src-supplier=Avnet+Asia; ComponentLink4URL: https://www.distrelec.biz/Web/Downloads/xx/_e/jqLM2596x-xx_e.pdf?mime=application%2Fpdf&src-supplier=Distrelec; ComponentLink4Description: https://www.distrelec.biz/Web/Downloads/xx/_e/jqLM2596x-xx_e.pdf?mime=application%2Fpdf&src-supplier=Distrelec; ComponentLink3URL: http://www.farnell.com/datasheets/1874590.pdf?src-supplier=Element14; ComponentLink3Description: http://www.farnell.com/datasheets/1874590.pdf?src-supplier=Element14; ComponentLink2URL: http://www.ti.com/lit/ds/symlink/lm2596.pdf?src-supplier=Digi-Key; ComponentLink2Description: http://www.ti.com/lit/ds/symlink/lm2596.pdf?src-supplier=Digi-Key; ComponentLink1URL: https://www.verical.com/server-webapp/html/getDatasheet?partID=112894&src-supplier=Verical; ComponentLink1Description: https://www.verical.com/server-webapp/html/getDatasheet?partID=112894&src-supplier=Verical; Component Kind: Standard; Case/Package: TO-263; Library Name: FAMIS.SchLib
U3 = STM32L053C8T6: IC MCU 32BIT 64KB FLASH 48LQFP; Manufacturer: STMicroelectronics; Watchdog Timer: Yes; RAM Size: 8KB; Peripherals: Brown-out Detect/Reset,POR,PWM,WDT,DMA,LCD; Oscillator Type: Internal; Number of Timers/Counters: 5; Number of Programmable I/O: 37; Number of ADC Channels: 10; Min Supply Voltage: 1.8V; Max Supply Voltage: 3.6V; Max Power Dissipation: 363mW; Max Frequency: 32MHz; Interface: I2C,SPI,USB,IrDA,UART,USART,I2S; Data Bus Width: 32b; Core Architecture: ARM; Weight: 0.006409oz; Supplier Part Number 1: 497-14906-ND; Supplier 1: Digi-Key; Stock 1: 7814; REACH SVHC: No SVHC; Pricing 1: 1=4.81, 10=4.317, 25=4.0808, 80=3.5366, 250=3.3553, 500=3.0107, 1000=2.645 (USD); Packaging: Tray; Package Quantity: 1500; Number of Pins: 48; Number of I/Os: 37; Mount: Surface Mount; Min Operating Temperature: -40°C; Memory Type: FLASH; Memory Size: 64KB; Max Operating Temperature: 85°C; Manufacturer Part Number: STM32L053C8T6; Frequency: 32MHz; ComponentLink1URL: http://www.st.com/st-web-ui/static/active/en/resource/technical/document/datasheet/DM00105960.pdf?src-supplier=Avnet; ComponentLink1Description: http://www.st.com/st-web-ui/static/active/en/resource/technical/document/datasheet/DM00105960.pdf?src-supplier=Avnet; Component Kind: Standard; Case/Package: LQFP; Library Name: FAMIS.SchLib
U4 = AP2112K-3.3TRG1: IC REG LINEAR 3.3V 600MA SOT25; Manufacturer: Diodes; Quiescent Current: 55uA; Polarity: Positive; Output Type: Fixed; Number of Regulators: 1; Min Input Voltage: 2.5V; Max Input Voltage: 6V; Dropout Voltage: 250mV; Accuracy: 1.5%; Width: 1.7mm; Supplier Part Number 1: AP2112K-3.3TRG1DIDKR-ND; Supplier 1: Digi-Key; Stock 1: 20198; Pricing 1: 1=0.47, 10=0.355, 25=0.32, 100=0.1745, 250=0.1687, 500=0.1513, 1000=0.1164 (USD); Packaging: Tape and Reel; Package Quantity: 1; Output Voltage: 3.3V; Output Current: 600mA; Number of Pins: 5; Number of Outputs: 1; Mount: Surface Mount; Min Operating Temperature: -40°C; Max Output Current: 600mA; Max Operating Temperature: 85°C; Manufacturer Part Number: AP2112K-3.3TRG1; Length: 3.1mm; Lead Free: Lead Free; Height: 1.3mm; ComponentLink2URL: https://www.diodes.com/assets/Datasheets/AP2112.pdf?src-supplier=Digi-Key; ComponentLink2Description: https://www.diodes.com/assets/Datasheets/AP2112.pdf?src-supplier=Digi-Key; ComponentLink1URL: http://www.diodes.com/datasheets/AP2112.pdf?src-supplier=Avnet; ComponentLink1Description: http://www.diodes.com/datasheets/AP2112.pdf?src-supplier=Avnet; Component Kind: Standard; Case/Package: SOT-23-5; Library Name: FAMIS.SchLib
Y1 = AS-16.000MAHK-B: Crystals 16.000MHz 30ppm 20pF -40C to 85C; Manufacturer: TXC; ESR (Equivalent Series Resistance): 50R; Width: 0.197inch; Tolerance: 30ppm; Termination: Radial; Supplier Part Number 1: 887-1591-ND; Supplier 1: Digi-Key; Stock 1: 672; REACH SVHC: No SVHC; Ratings: AEC-Q200; Pricing 1: 1=0.54, 10=0.45, 50=0.405, 100=0.36, 500=0.342, 1000=0.288, 2500=0.27, 5000=0.261, 10000=0.252 (USD); Packaging: Bulk; Package Quantity: 1000; Number of Pins: 2; Mount: Through Hole; Min Operating Temperature: -40°C; Max Operating Temperature: 85°C; Manufacturer Part Number: AS-16.000MAHK-B; Load Capacitance: 20pF; Length: 11.5mm; Height: 0.145inch; Frequency Tolerance: 30ppm; Frequency Stability: 30ppm; Frequency: 16MHz; Depth: 5mm; ComponentLink5URL: http://components.arrow.com/part/dataview/57418299S591311N4258?src-supplier=Arrow+Electronics; ComponentLink5Description: http://components.arrow.com/part/dataview/57418299S591311N4258?src-supplier=Arrow+Electronics; ComponentLink4URL: http://www.mouser.com/ds/2/417/as-automotive-10001.pdf?src-supplier=Mouser; ComponentLink4Description: http://www.mouser.com/ds/2/417/as-automotive-10001.pdf?src-supplier=Mouser; ComponentLink3URL: http://www.farnell.com/datasheets/1639152.pdf?src-supplier=Farnell; ComponentLink3Description: http://www.farnell.com/datasheets/1639152.pdf?src-supplier=Farnell; ComponentLink2URL: http://www.farnell.com/datasheets/1639152.pdf?src-supplier=Element14; ComponentLink2Description: http://www.farnell.com/datasheets/1639152.pdf?src-supplier=Element14; ComponentLink1URL: http://www.txccrystal.com/images/pdf/as-automotive.pdf?src-supplier=Digi-Key; ComponentLink1Description: http://www.txccrystal.com/images/pdf/as-automotive.pdf?src-supplier=Digi-Key; Component Kind: Standard; Library Name: FAMIS.SchLib
